# Altium schematic sheet obc_main_3.0.SchDoc
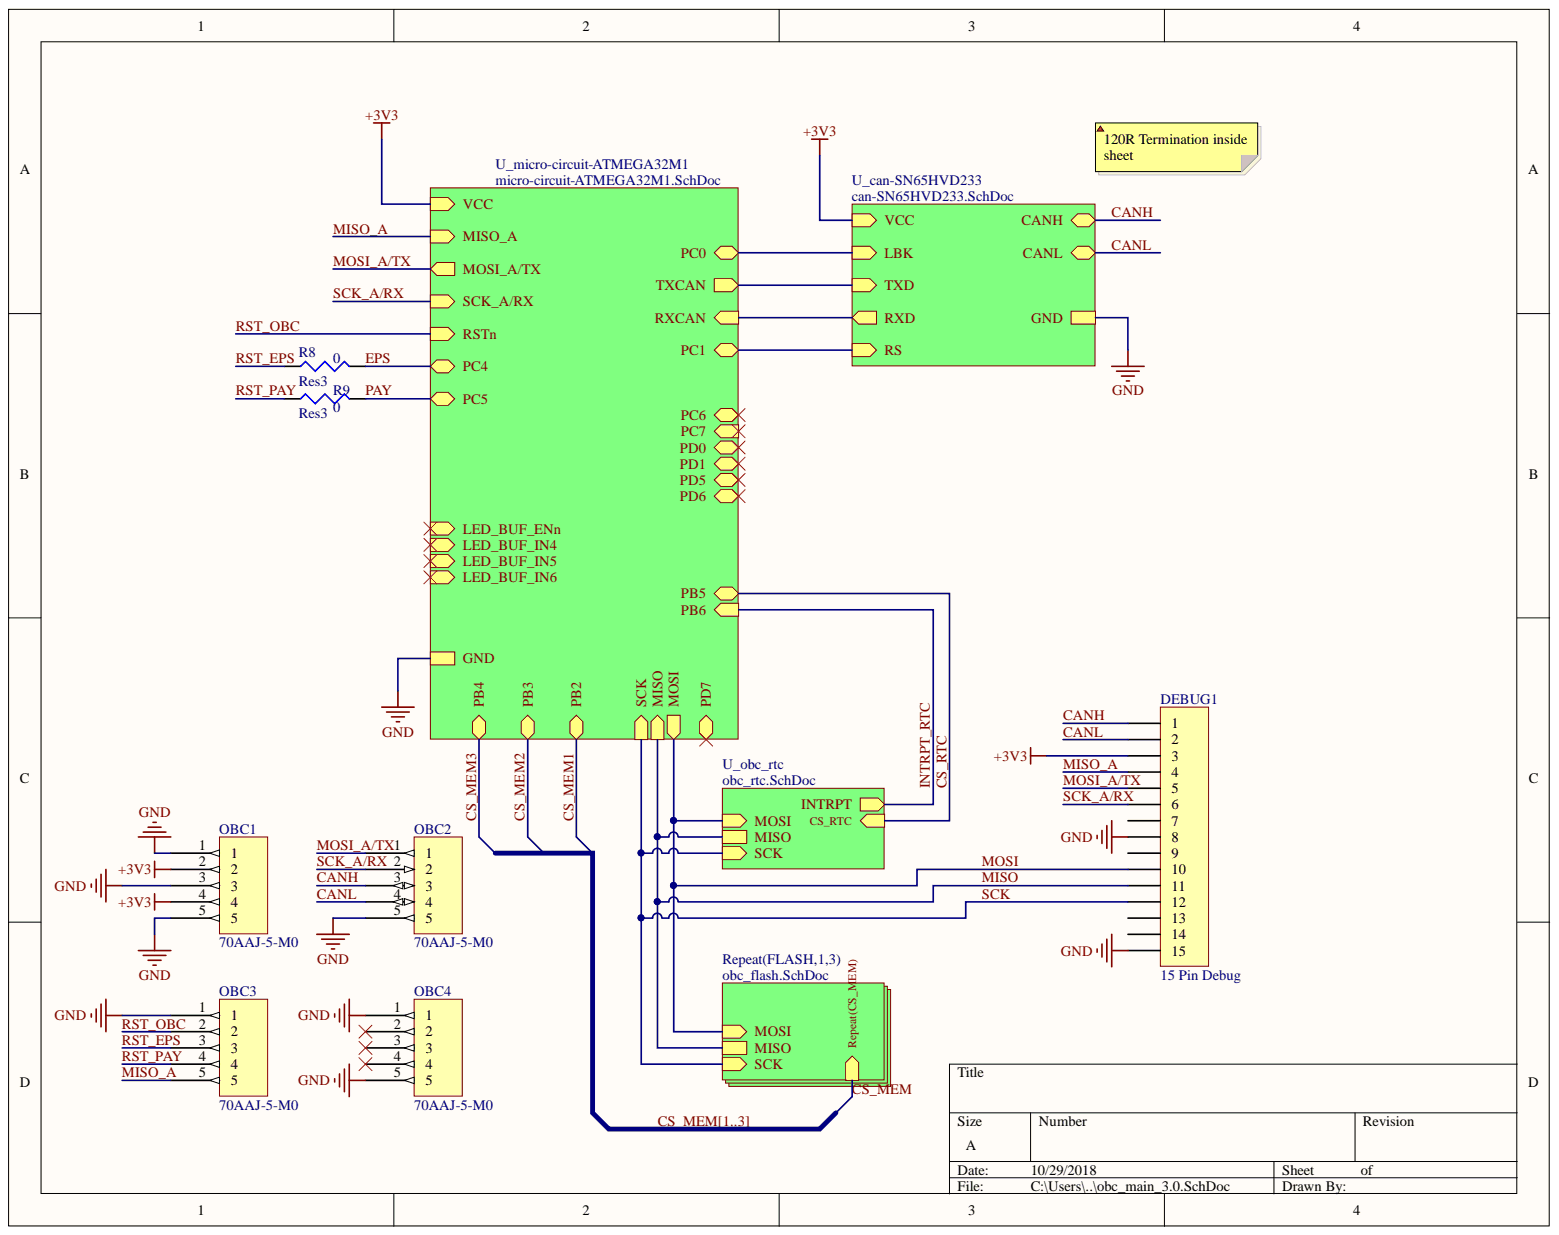
[diagram p0: obc_main_3.0.SchDoc]

## Parts
DEBUG1  15 Pin Debug  [HDR1X15]
OBC1  70AAJ-5-M0  [70AAJ-5-M0]
OBC2  70AAJ-5-M0  [70AAJ-5-M0]
OBC3  70AAJ-5-M0  [70AAJ-5-M0]
OBC4  70AAJ-5-M0  [70AAJ-5-M0]
R8  Res3  value 0  [J1-0603]
R9  Res3  value 0  [J1-0603]

## Nets
+3V3: DEBUG1.3 OBC1.2 OBC1.4
GND: DEBUG1.8 DEBUG1.15 OBC1.1 OBC1.3 OBC1.5 OBC2.5 OBC3.1 OBC4.1 OBC4.5
CANH: DEBUG1.1 OBC2.3
CANL: DEBUG1.2 OBC2.4
EPS: R8.2
MISO: DEBUG1.11
MISO_A: DEBUG1.4 OBC3.5
MOSI: DEBUG1.10
MOSI_A/TX: DEBUG1.5 OBC2.1
PAY: R9.2
RST_EPS: OBC3.3 R8.1
RST_OBC: OBC3.2
RST_PAY: OBC3.4 R9.1
SCK: DEBUG1.12
SCK_A/RX: DEBUG1.6 OBC2.2
NetDEBUG1_7: DEBUG1.7
NetDEBUG1_9: DEBUG1.9
NetDEBUG1_13: DEBUG1.13
NetDEBUG1_14: DEBUG1.14
NetOBC4_2: OBC4.2
NetOBC4_3: OBC4.3
NetOBC4_4: OBC4.4
CS_MEM1:  [also labelled CS_MEM]
CS_MEM2:  [also labelled CS_MEM]
CS_MEM3:  [also labelled CS_MEM]
CS_RTC:
INTRPT_RTC:
LBK:
LED_BUF_ENn:
LED_BUF_IN4:
LED_BUF_IN5:
LED_BUF_IN6:
PC6:
PC7:
PD0:
PD1:
PD5:
PD6:
PD7:
RS:
RXD:
TXD:

## Library data of the parts
DEBUG1 = Header 15: Header, 15-Pin; Component Kind: Standard; LatestRevisionDate: 17-Jul-2002; LatestRevisionNote: Re-released for DXP Platform.; Library Name: Miscellaneous Connectors.IntLib; Signal Integrity: Connector; Publisher: Altium Limited
R8 R9 = Res3: Resistor; Component Kind: Standard; LatestRevisionDate: 29-May-2009; LatestRevisionNote: IPC-7351 Footprint Added.; Library Name: Miscellaneous Devices.IntLib; PackageDescription: Chip Resistor; PackageReference: J1-0603; Published: 8-Jun-2000; Signal Integrity: Res; Simulation: RESISTOR; Publisher: Altium Limited
OBC1-OBC4 = 70AAJ-5-M0: Component Kind: Standard; Library Name: CONNs..SchLib
